# Altium schematic sheet DAC_Filter.SchDoc
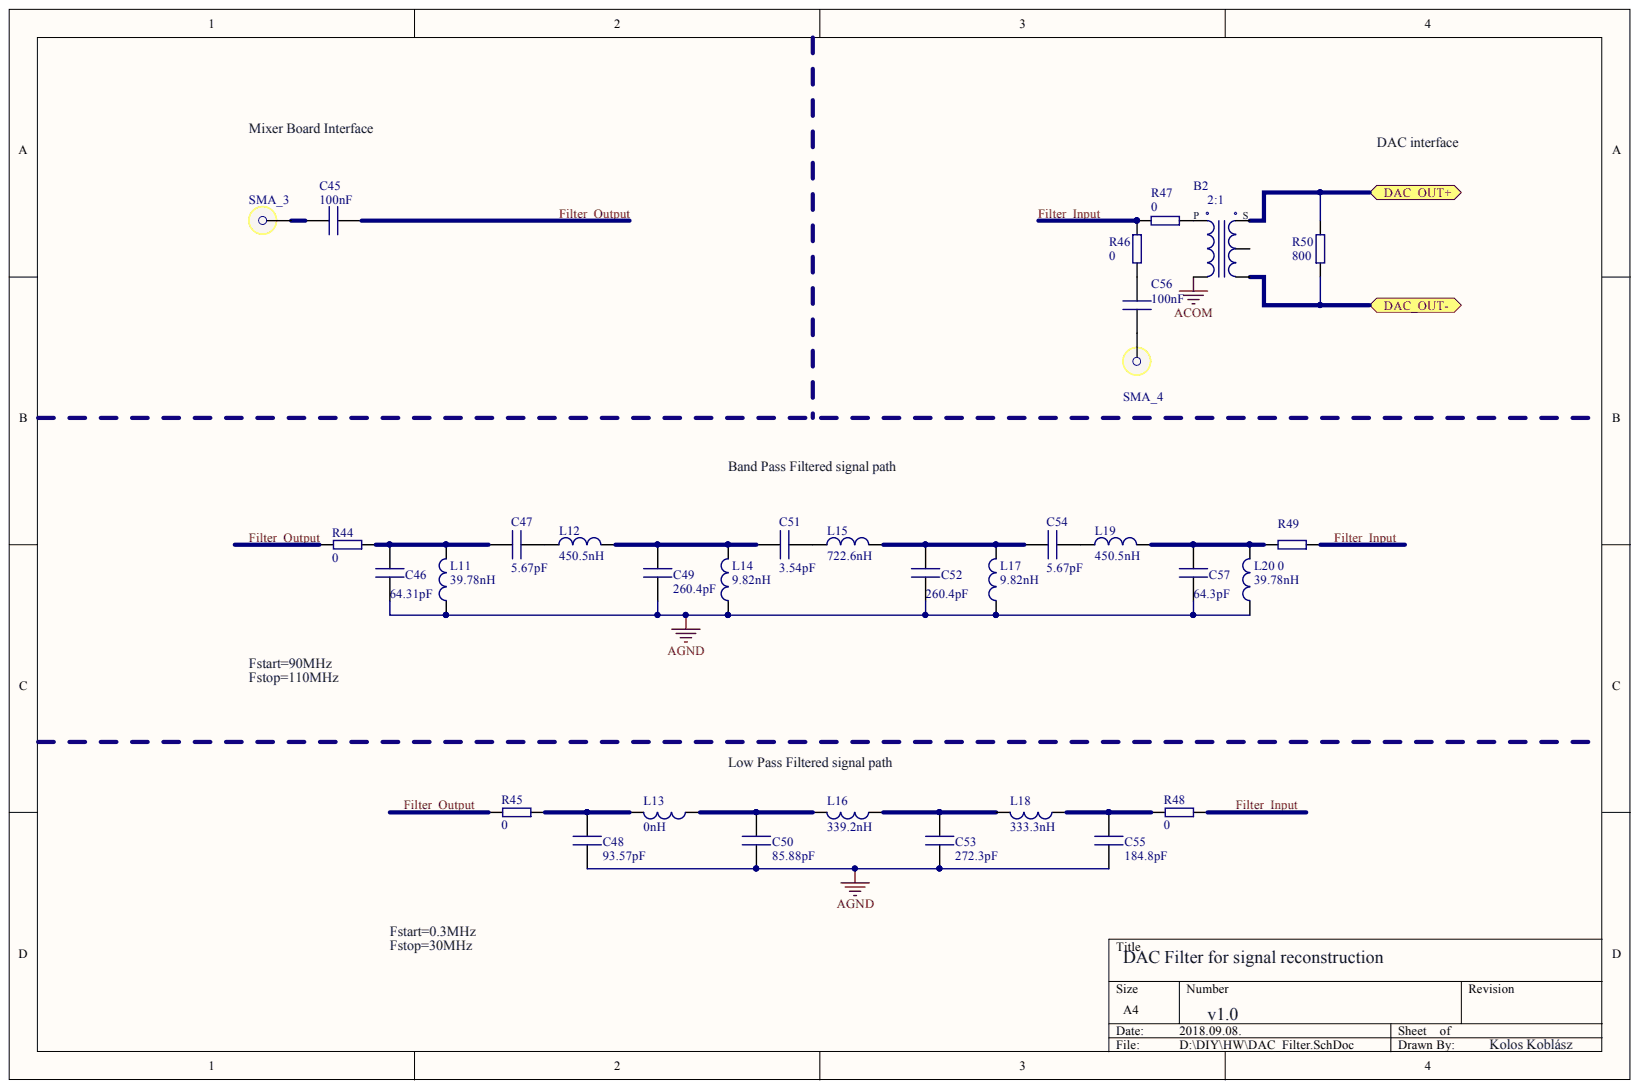
[diagram p0: DAC_Filter.SchDoc]

## Parts
B2  ImpedanceTransformation P: 2:1  [SMD]  (hidden comment: Balun)
C45  value 100nF  (hidden comment: Cap1)
C46  value 64.31pF  (hidden comment: Cap1)
C47  value 5.67pF  (hidden comment: Cap1)
C48  value 93.57pF  (hidden comment: Cap1)
C49  value 260.4pF  (hidden comment: Cap1)
C50  value 85.88pF  (hidden comment: Cap1)
C51  value 3.54pF  (hidden comment: Cap1)
C52  value 260.4pF  (hidden comment: Cap1)
C53  value 272.3pF  (hidden comment: Cap1)
C54  value 5.67pF  (hidden comment: Cap1)
C55  value 184.8pF  (hidden comment: Cap1)
C56  value 100nF  (hidden comment: Cap1)
C57  value 64.3pF  (hidden comment: Cap1)
L11  value 39.78nH  [SMD]  (hidden comment: Inductor)
L12  value 450.5nH  [SMD]  (hidden comment: Inductor)
L13  value 0nH  [SMD]  (hidden comment: Inductor)
L14  value 9.82nH  [SMD]  (hidden comment: Inductor)
L15  value 722.6nH  [SMD]  (hidden comment: Inductor)
L16  value 339.2nH  [SMD]  (hidden comment: Inductor)
L17  value 9.82nH  [SMD]  (hidden comment: Inductor)
L18  value 333.3nH  [SMD]  (hidden comment: Inductor)
L19  value 450.5nH  [SMD]  (hidden comment: Inductor)
L20  value 39.78nH  [SMD]  (hidden comment: Inductor)
R44  value 0  [SMD]  (hidden comment: Resistor)
R45  value 0  [SMD]  (hidden comment: Resistor)
R46  value 0  [SMD]  (hidden comment: Resistor)
R47  value 0  [SMD]  (hidden comment: Resistor)
R48  value 0  [SMD]  (hidden comment: Resistor)
R49  Resistor  [SMD]  (value not drawn)
R50  value 800  [SMD]  (hidden comment: Resistor)
SMA_3  SMA1  (value not drawn)
SMA_4  SMA1  (value not drawn)

## Ports
DAC_OUT+, DAC_OUT-

## Nets
ACOM: B2.4
AGND: C46.2 C48.1 C49.2 C50.1 C52.2 C53.1 C55.1 C57.2 L11.1 L14.1 L17.1 L20.1
FILTER_INPUT: R46.1 R47.2 R48.1 R49.1
FILTER_OUTPUT: C45.1 R44.2 R45.2
NetB2_1: B2.1 R50.2
NetB2_3: B2.3 R50.1
NetB2_5: B2.5 R47.1
NetC45_2: C45.2 SMA_3.1
NetC46_1: C46.1 C47.1 L11.2 R44.1
NetC47_2: C47.2 L12.1
NetC48_2: C48.2 L13.2 R45.1
NetC49_1: C49.1 C51.1 L12.2 L14.2
NetC50_2: C50.2 L13.1 L16.2
NetC51_2: C51.2 L15.1
NetC52_1: C52.1 C54.1 L15.2 L17.2
NetC53_2: C53.2 L16.1 L18.2
NetC54_2: C54.2 L19.1
NetC55_2: C55.2 L18.1 R48.2
NetC56_1: C56.1 R46.2
NetC56_2: C56.2 SMA_4.1
NetC57_1: C57.1 L19.2 L20.2 R49.2

Not connected: B2 2

## Library data of the parts
B2 = Balun1: SMD Balun; MaxFrequency: 500MHz; MinFrequency: 5MHz; Component Kind: Standard; Library Name: RadioProjectSchLib.SchLib
C45-C57 = Cap1: SMD Capacitor; MaxVolt: 16V; Precision: 1%; Component Kind: Standard; Library Name: RadioProjectSchLib.SchLib
L11-L20 = Ind1: SMD Inductor; MaxCurent: 100mA; Precision: 10%; Component Kind: Standard; Library Name: RadioProjectSchLib.SchLib
R44-R48 R50 = Res1: SMD Resistor; MaxPower: 0.1W; Precision: 1%; Component Kind: Standard; Library Name: RadioProjectSchLib.SchLib
R49 = Res1: SMD Resistor; MaxPower: 0.1W; Precision: 1%; Value: 0; Component Kind: Standard; Library Name: RadioProjectSchLib.SchLib
SMA_3 SMA_4 = SMA1: SMA Female; Shape: 90°; Component Kind: Standard; Library Name: RadioProjectSchLib.SchLib; Mounting: TroughHole
